# Altium schematic sheet MLSTM32.SchDoc
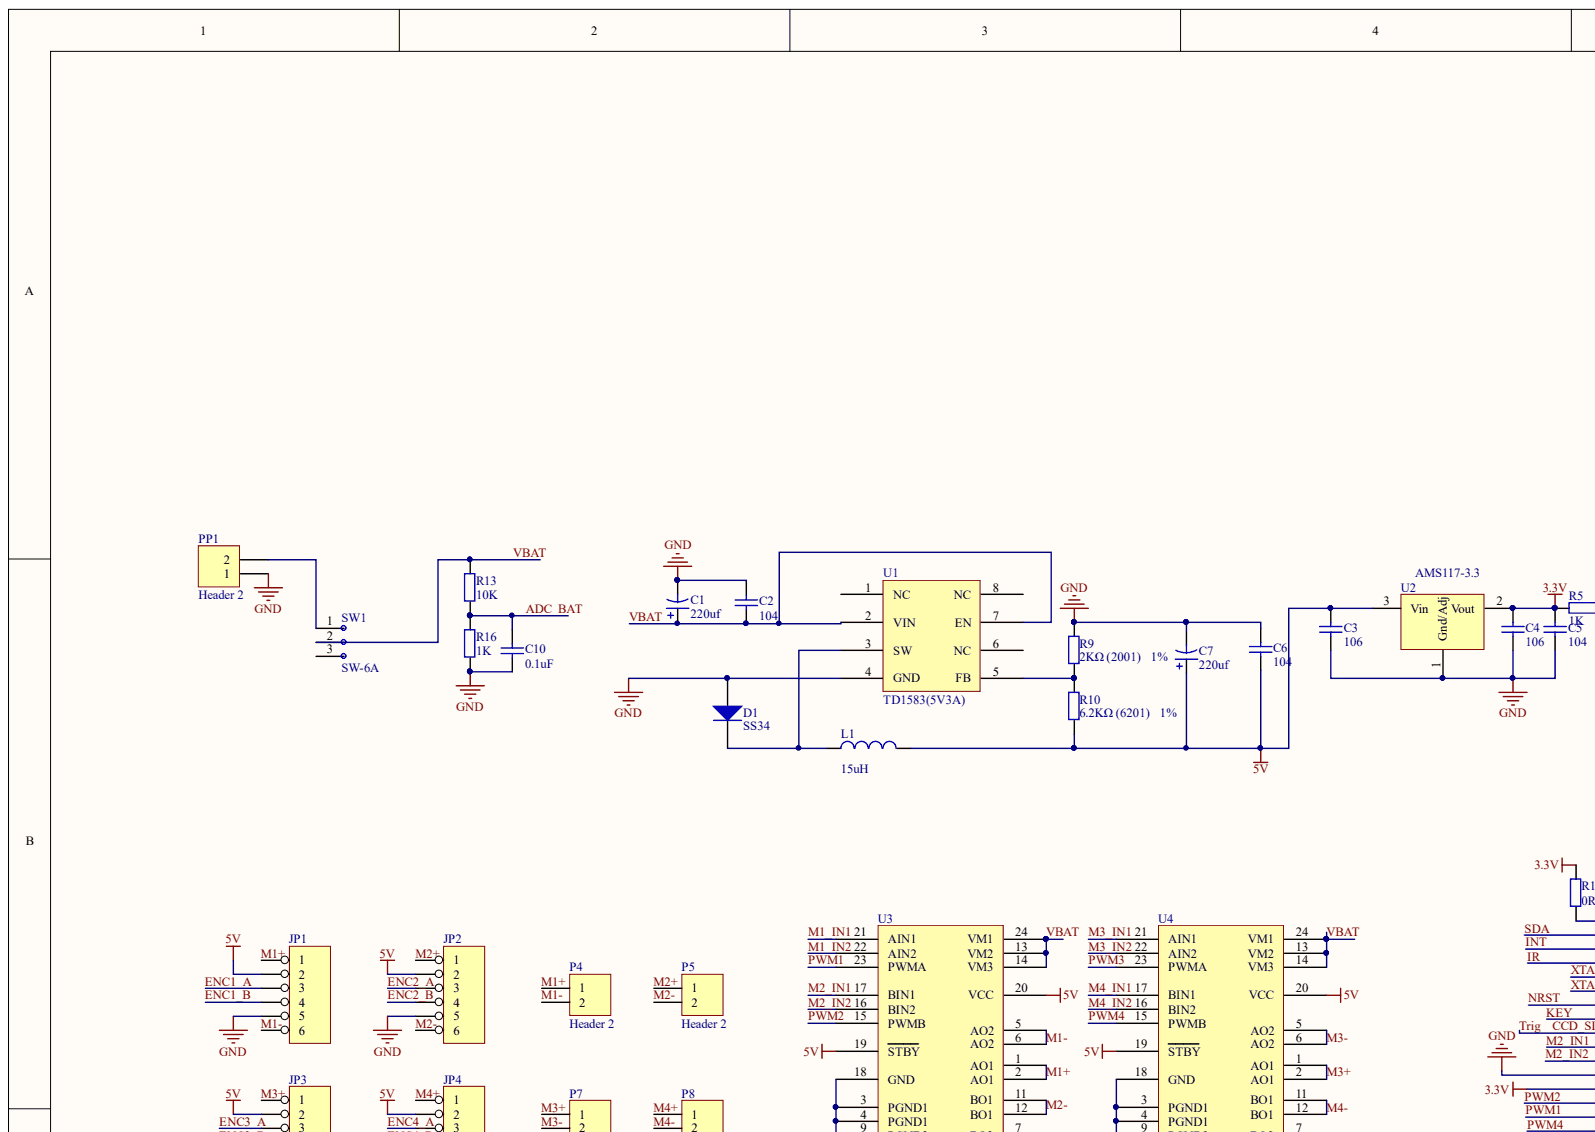
[diagram p0: MLSTM32.SchDoc, top left]
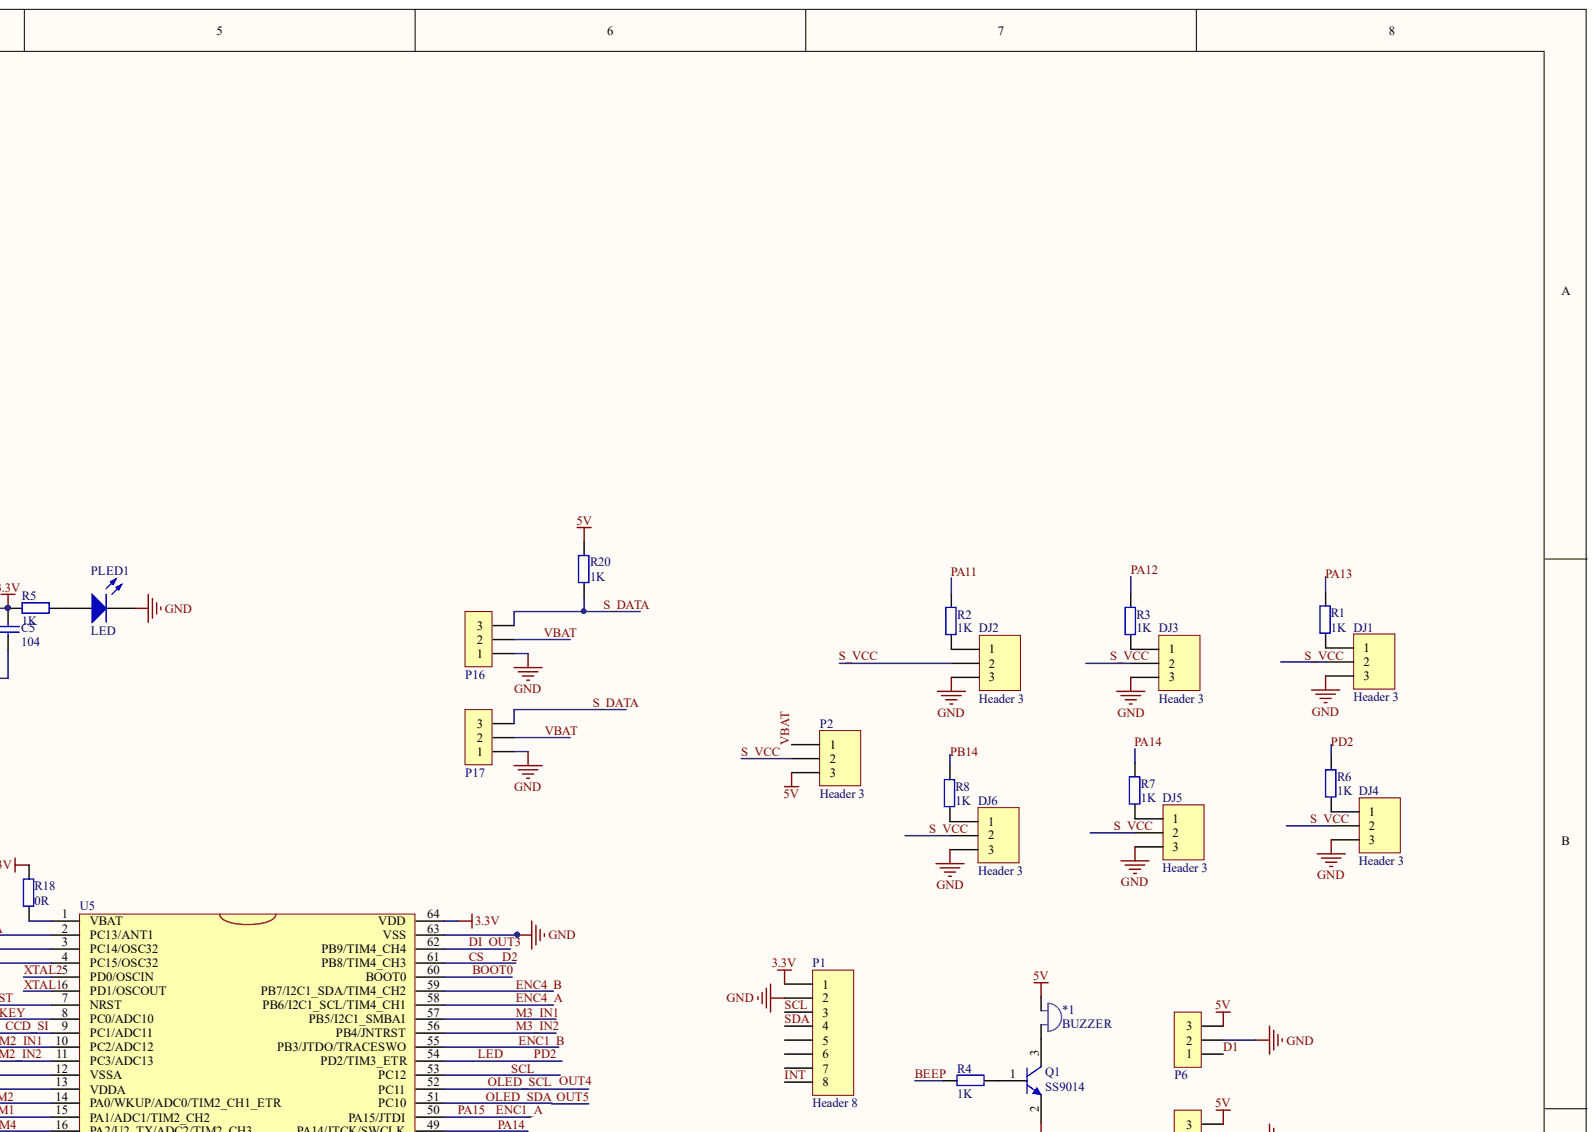
[diagram p1: MLSTM32.SchDoc, top right]
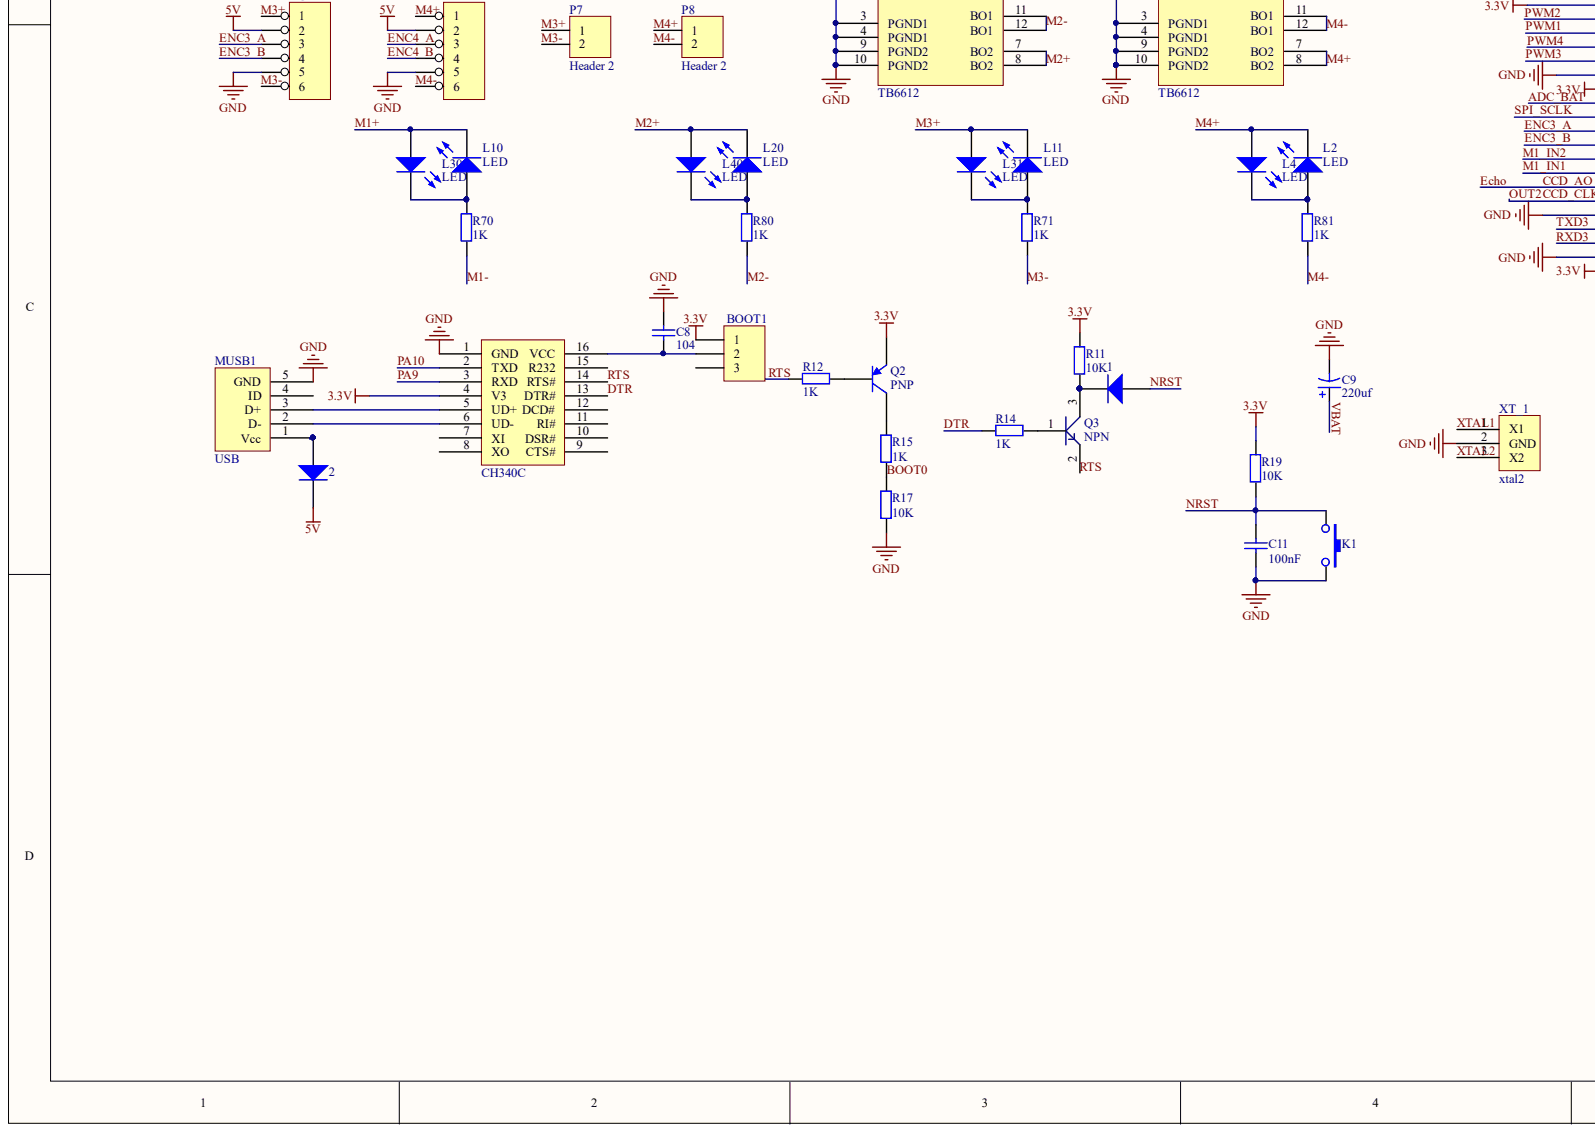
[diagram p2: MLSTM32.SchDoc, bottom left]
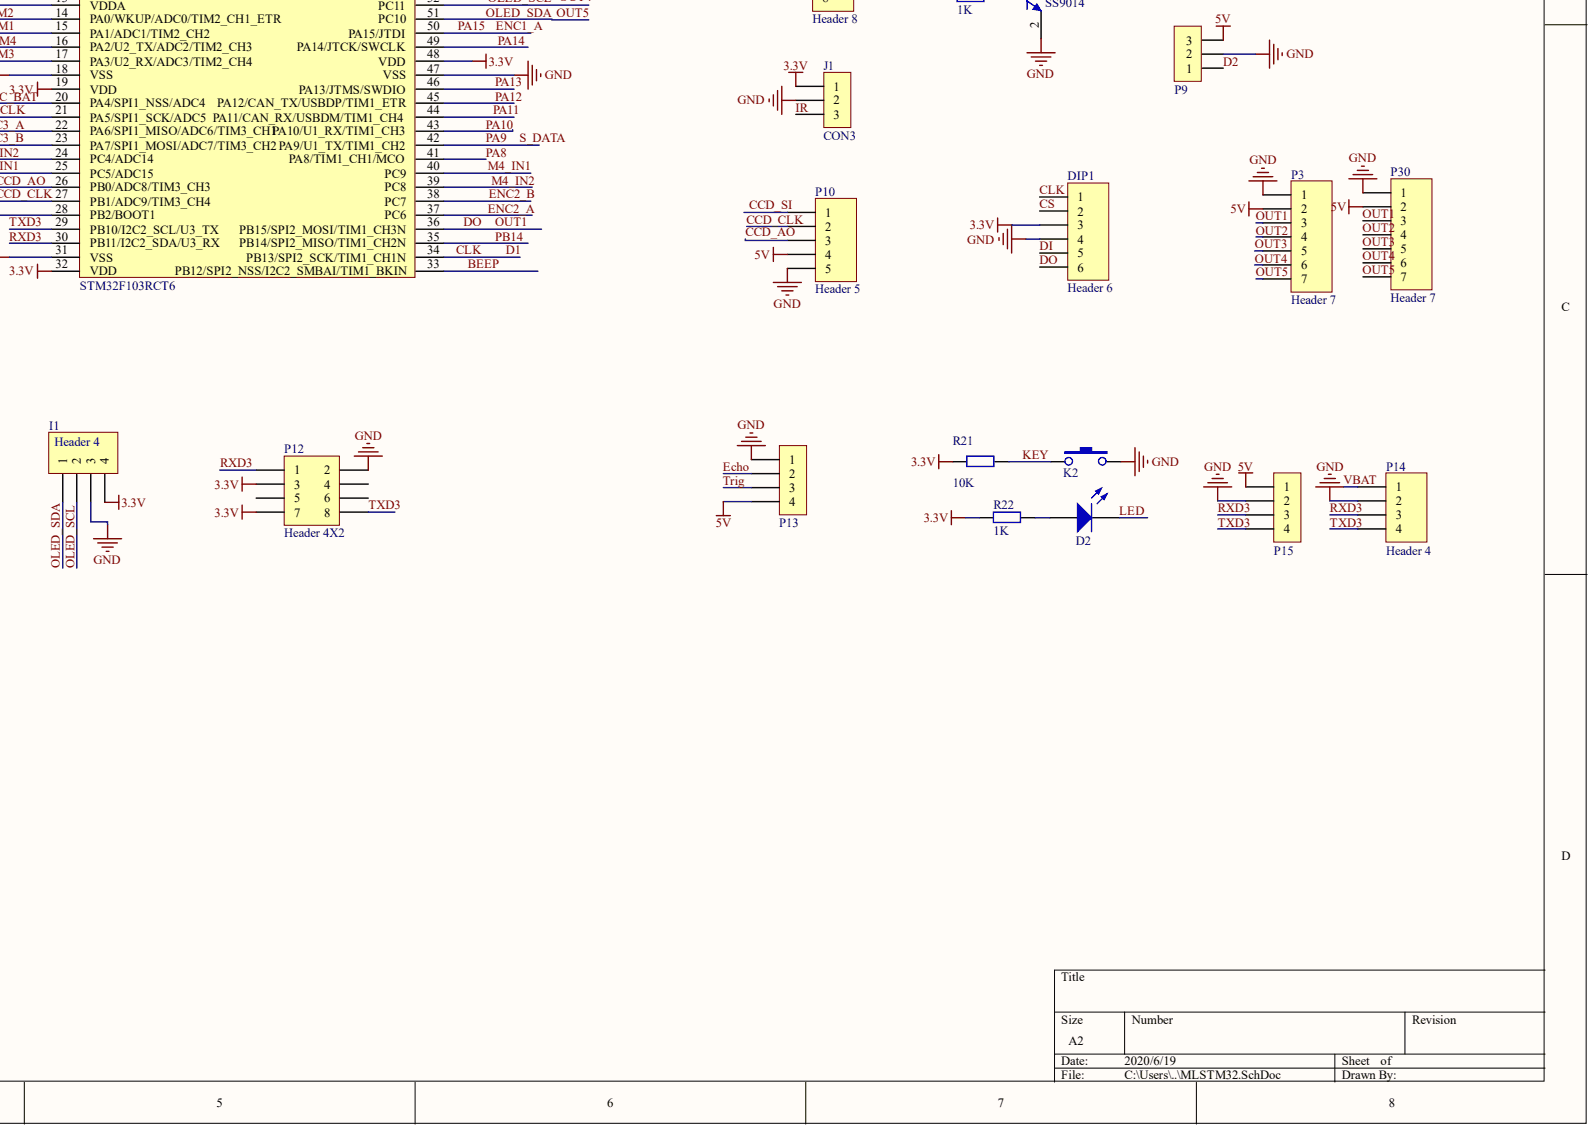
[diagram p3: MLSTM32.SchDoc, bottom right]

## Parts
1  Diode 1N4007  (value not drawn)
2  Diode 1N4007  (value not drawn)
*1  BUZZER
BOOT1  Header 3  (value not drawn)
C1  value 220uf  (hidden comment: Cap Pol1)
C2  value 104  (hidden comment: Cap)
C3  value 106  (hidden comment: Cap)
C4  value 106  (hidden comment: Cap)
C5  value 104  (hidden comment: Cap)
C6  value 104  (hidden comment: Cap)
C7  value 220uf  (hidden comment: Cap Pol1)
C8  value 104  (hidden comment: Cap)
C9  value 220uf  (hidden comment: Cap Pol1)
C10  value 0.1uF  (hidden comment: Cap)
C11  value 100nF  (hidden comment: Cap)
CH1  CH340C
D1  SS34
D2  LED0  (value not drawn)
DIP1  Header 6
DJ1  Header 3
DJ2  Header 3
DJ3  Header 3
DJ4  Header 3
DJ5  Header 3
DJ6  Header 3
I1  Header 4
J1  CON3
JP1  HEADER 6  (value not drawn)
JP2  HEADER 6  (value not drawn)
JP3  HEADER 6  (value not drawn)
JP4  HEADER 6  (value not drawn)
K1  BUTTON  (value not drawn)
K2  BUTTON  (value not drawn)
L1  value 15uH  (hidden comment: 15uH/ CD104R,3A电感)
L2  LED
L4  LED
L10  LED
L11  LED
L20  LED
L30  LED
L31  LED
L40  LED
MUSB1  USB
P1  Header 8
P2  Header 3
P3  Header 7
P4  Header 2
P5  Header 2
P6  CON3  (value not drawn)
P7  Header 2
P8  Header 2
P9  CON3  (value not drawn)
P10  Header 5
P12  Header 4X2
P13  CON4  (value not drawn)
P14  Header 4
P15  CON4  (value not drawn)
P16  CON3  (value not drawn)
P17  CON3  (value not drawn)
P30  Header 7
PLED1  LED
PP1  Header 2
Q1  SS9014
Q2  PNP
Q3  NPN
R1  value 1K  (hidden comment: Res2)
R2  value 1K  (hidden comment: Res2)
R3  value 1K  (hidden comment: Res2)
R4  value 1K  (hidden comment: Res2)
R5  value 1K  (hidden comment: Res2)
R6  value 1K  (hidden comment: Res2)
R7  value 1K  (hidden comment: Res2)
R8  value 1K  (hidden comment: Res2)
R9  2KΩ (2001) ±1%  (value not drawn)
R10  6.2KΩ (6201) ±1%
R11  value 10K  (hidden comment: Res2)
R12  value 1K  (hidden comment: Res2)
R13  value 10K  (hidden comment: Res2)
R14  value 1K  (hidden comment: Res2)
R15  value 1K  (hidden comment: Res2)
R16  value 1K  (hidden comment: Res2)
R17  value 10K  (hidden comment: Res2)
R18  value 0R  (hidden comment: Res1)
R19  value 10K  (hidden comment: Res1)
R20  value 1K  (hidden comment: Res2)
R21  value 10K  (hidden comment: Res1)
R22  value 1K  (hidden comment: Res1)
R70  value 1K  (hidden comment: Res2)
R71  value 1K  (hidden comment: Res2)
R80  value 1K  (hidden comment: Res2)
R81  value 1K  (hidden comment: Res2)
SW1  SW-6A
U1  TD1583(5V3A)
U2  AMS117-3.3
U3  TB6612
U4  TB6612
U5  STM32F103RCT6
XT_1  xtal2

## Nets
3.3V: BOOT1.1 C4.2 C5.2 CH1.4(V3) DIP1.3 I1.4 J1.1 P1.1 P12.3 P12.7 Q2.2 R5.2 R11.1 R18.2 R19.2 R21.1 R22.1 U2.2 U5.13(VDDA) U5.19(VDD) U5.32(VDD) U5.48(VDD) U5.64(VDD)
5V: 2.2 *1.1 C3.2 C6.2 C7.1 JP1.2 JP2.2 JP3.2 JP4.2 L1.2 P2.3 P3.2 P6.3 P9.3 P10.4 P13.4 P15.1 P30.2 R10.1 R20.1 U2.3 U3.19(STBY) U3.20(VCC) U4.19(STBY) U4.20(VCC)
GND: C1.2 C2.2 C3.1 C4.1 C5.1 C6.1 C7.2 C8.2 C9.2 C10.1 C11.1 CH1.1(GND) D1.1 DIP1.4 DJ1.3 DJ2.3 DJ3.3 DJ4.3 DJ5.3 DJ6.3 I1.3 J1.2 JP1.5 JP2.5 JP3.5 JP4.5 K1.2 K2.2 MUSB1.5(GND) P1.2 P3.1 P6.2 P9.2 P10.5 P12.2 P13.1 P14.2 P15.2 P16.1 P17.1 P30.1 PLED1.2 PP1.1 Q1.2 R9.2 R16.2 R17.1 U1.4(GND) U2.1 U3.3(PGND1) U3.4(PGND1) U3.9(PGND2) U3.10(PGND2) U3.18(GND) U4.3(PGND1) U4.4(PGND1) U4.9(PGND2) U4.10(PGND2) U4.18(GND) U5.12(VSSA) U5.18(VSS) U5.28(PB2/BOOT1) U5.31(VSS) U5.47(VSS) U5.63(VSS) XT_1.2
ADC_BAT: C10.2 R13.2 R16.1 U5.20(PA4/SPI1_NSS/ADC4)
BEEP: R4.2 U5.33(PB12/SPI2_NSS/I2C2_SMBAI/TIM1_BKIN)
BOOT0: R15.1 R17.2 U5.60(BOOT0)
CCD_AO: P10.3 P13.2 U5.26(PB0/ADC8/TIM3_CH3)
CCD_CLK: P3.4 P10.2 P30.4 U5.27(PB1/ADC9/TIM3_CH4)
CCD_SI: P10.1 P13.3 U5.9(PC1/ADC11)
CLK: DIP1.1 P6.1 U5.34(PB13/SPI2_SCK/TIM1_CH1N)
CS: DIP1.2 P9.1 U5.61(PB8/TIM4_CH3)
DI: DIP1.5 P3.5 P30.5 U5.62(PB9/TIM4_CH4)
DO: DIP1.6 P3.3 P30.3 U5.36(PB15/SPI2_MOSI/TIM1_CH3N)
DTR: CH1.13(DTR#) R14.2
ENC1_A: JP1.3 U5.50(PA15/JTDI)
ENC1_B: JP1.4 U5.55(PB3/JTDO/TRACESWO)
ENC2_A: JP2.3 U5.37(PC6)
ENC2_B: JP2.4 U5.38(PC7)
ENC3_A: JP3.3 U5.22(PA6/SPI1_MISO/ADC6/TIM3_CH1)
ENC3_B: JP3.4 U5.23(PA7/SPI1_MOSI/ADC7/TIM3_CH2)
ENC4_A: JP4.3 U5.58(PB6/I2C1_SCL/TIM4_CH1)
ENC4_B: JP4.4 U5.59(PB7/I2C1_SDA/TIM4_CH2)
INT: P1.8 U5.3(PC14/OSC32)
IR: J1.3 U5.4(PC15/OSC32)
KEY: K2.1 R21.2 U5.8(PC0/ADC10)
LED: D2.2 R6.1 U5.54(PD2/TIM3_ETR)
M1+: JP1.1 L10.2 L30.1 P4.1 U3.1(AO1) U3.2(AO1)
M1-: JP1.6 P4.2 R70.1 U3.5(AO2) U3.6(AO2)
M1_IN1: U3.21(AIN1) U5.25(PC5/ADC15)
M1_IN2: U3.22(AIN2) U5.24(PC4/ADC14)
M2+: JP2.1 L20.2 L40.1 P5.1 U3.7(BO2) U3.8(BO2)
M2-: JP2.6 P5.2 R80.1 U3.11(BO1) U3.12(BO1)
M2_IN1: U3.17(BIN1) U5.10(PC2/ADC12)
M2_IN2: U3.16(BIN2) U5.11(PC3/ADC13)
M3+: JP3.1 L11.2 L31.1 P7.1 U4.1(AO1) U4.2(AO1)
M3-: JP3.6 P7.2 R71.1 U4.5(AO2) U4.6(AO2)
M3_IN1: U4.21(AIN1) U5.57(PB5/I2C1_SMBAI)
M3_IN2: U4.22(AIN2) U5.56(PB4/JNTRST)
M4+: JP4.1 L2.2 L4.1 P8.1 U4.7(BO2) U4.8(BO2)
M4-: JP4.6 P8.2 R81.1 U4.11(BO1) U4.12(BO1)
M4_IN1: U4.17(BIN1) U5.40(PC9)
M4_IN2: U4.16(BIN2) U5.39(PC8)
NRST: 1.1 C11.2 K1.1 R19.1 U5.7(NRST)
OLED_SCL: I1.2 U5.52(PC11)
OLED_SDA: I1.1 P3.7 P30.7 U5.51(PC10)
OUT4: P3.6 P30.6
PA8: U5.41(PA8/TIM1_CH1/MCO)
PA9: CH1.3(RXD) P16.3 P17.3 R20.2 U5.42(PA9/U1_TX/TIM1_CH2)
PA10: CH1.2(TXD) U5.43(PA10/U1_RX/TIM1_CH3)
PA11: R2.1 U5.44(PA11/CAN_RX/USBDM/TIM1_CH4)
PA12: R3.1 U5.45(PA12/CAN_TX/USBDP/TIM1_ETR)
PA13: R1.1 U5.46(PA13/JTMS/SWDIO)
PA14: R7.1 U5.49(PA14/JTCK/SWCLK)
PB14: R8.1 U5.35(PB14/SPI2_MISO/TIM1_CH2N)
PWM1: U3.23(PWMA) U5.15(PA1/ADC1/TIM2_CH2)
PWM2: U3.15(PWMB) U5.14(PA0/WKUP/ADC0/TIM2_CH1_ETR)
PWM3: U4.23(PWMA) U5.17(PA3/U2_RX/ADC3/TIM2_CH4)
PWM4: U4.15(PWMB) U5.16(PA2/U2_TX/ADC2/TIM2_CH3)
RTS: CH1.14(RTS#) Q3.2 R12.1
RXD3: P12.1 P14.3 P15.3 U5.30(PB11/I2C2_SDA/U3_RX)
S_VCC: DJ1.2 DJ2.2 DJ3.2 DJ4.2 DJ5.2 DJ6.2 P2.2
SCL: P1.3 U5.53(PC12)
SDA: P1.4 U5.2(PC13/ANT1)
SPI_SCLK: U5.21(PA5/SPI1_SCK/ADC5)
TXD3: P12.8 P14.4 P15.4 U5.29(PB10/I2C2_SCL/U3_TX)
VBAT: C1.1 C2.1 C9.1 P2.1 P14.1 P16.2 P17.2 R13.1 SW1.2 U1.2(VIN) U1.7(EN) U3.13(VM2) U3.14(VM3) U3.24(VM1) U4.13(VM2) U4.14(VM3) U4.24(VM1)
XTAL1: U5.6(PD1/OSCOUT) XT_1.1
XTAL2: U5.5(PD0/OSCIN) XT_1.3
Net1_2: 1.2 Q3.3 R11.2
Net2_1: 2.1 MUSB1.1(Vcc)
Net*1_2: *1.2 Q1.3
NetBOOT1_2: BOOT1.2 C8.1 CH1.16(VCC)
NetCH1_5: CH1.5(UD+) MUSB1.3(D+)
NetCH1_6: CH1.6(UD-) MUSB1.2(D-)
NetD1_2: D1.2 L1.1 U1.3(SW)
NetD2_1: D2.1 R22.2
NetDJ1_1: DJ1.1 R1.2
NetDJ2_1: DJ2.1 R2.2
NetDJ3_1: DJ3.1 R3.2
NetDJ4_1: DJ4.1 R6.2
NetDJ5_1: DJ5.1 R7.2
NetDJ6_1: DJ6.1 R8.2
NetL2_1: L2.1 L4.2 R81.2
NetL10_1: L10.1 L30.2 R70.2
NetL11_1: L11.1 L31.2 R71.2
NetL20_1: L20.1 L40.2 R80.2
NetPLED1_1: PLED1.1 R5.1
NetPP1_2: PP1.2 SW1.1
NetQ1_1: Q1.1 R4.1
NetQ2_1: Q2.1 R12.2
NetQ2_3: Q2.3 R15.2
NetQ3_1: Q3.1 R14.1
NetR9_1: R9.1 R10.2 U1.5(FB)
NetR18_1: R18.1 U5.1(VBAT)

Not connected: BOOT1 3; CH1 7(XI) 8(XO) 9(CTS#) 10(DSR#) 11(RI#) 12(DCD#) 15(R232); MUSB1 4(ID); P1 5 6 7; P12 4 5 6; SW1 3; U1 1(NC) 6(NC) 8(NC)

## Library data of the parts
L1 = (no symbol name): Code_IEC: 1005; Published: 8-Jun-2000; LatestRevisionDate: 29-May-2009; LatestRevisionNote: IPC-7351 Footprint Added.; PackageReference: 0402-A; PackageDescription: Chip Inductor; Code_JEITA: 0402; Publisher: Altium Limited
1 2 *1 BOOT1 C1-C11 CH1 D1 D2 DIP1 DJ1-DJ6 I1 J1 JP1-JP4 K1 K2 L2 L4 L10 L11 L20 L30 L31 L40 MUSB1 P1-P10 P12-P17 P30 PLED1 PP1 Q1-Q3 R1-R22 R70 R71 R80 R81 SW1 U1-U5 XT_1 = (no symbol name)
  1 2: Published: 8-Jun-2000; LatestRevisionDate: 17-Jul-2002; LatestRevisionNote: Re-released for DXP Platform.; DatasheetDocument: 1998; PackageDocument: Aug-1999; PackageReference: DO-41; Code_JEDEC: DO-204-AL; ComponentLink1Description: Manufacturer Link; ComponentLink1URL: http://www.fairchildsemi.com/; ComponentLink2Description: Datasheet; ComponentLink2URL: http://www.fairchildsemi.com/ds/1N%2F1N4001.pdf
  BOOT1 DJ1-DJ6 I1 P1-P5 P7 P8 P10 P12 P14 P30 PP1: LatestRevisionDate: 17-Jul-2002; LatestRevisionNote: Re-released for DXP Platform.
  C1 C7 C9: Published: 8-Jun-2000; LatestRevisionDate: 17-Jul-2002; LatestRevisionNote: Re-released for DXP Platform.; PackageReference: RB7.6-15
  C2-C6 C8 C10 C11: Published: 8-Jun-2000; LatestRevisionDate: 17-Jul-2002; LatestRevisionNote: Re-released for DXP Platform.; PackageReference: RAD-0.3
  D1: Published: 8-Jun-2000; LatestRevisionDate: 17-Jul-2002; LatestRevisionNote: Re-released for DXP Platform.; PackageVersion: Sep-1996; PackageReference: SMC; PackageDescription: DO-214-AB/SMC; 2 C-Bend Leads; Body 7.9 x 5.9 mm, inc. leads (LxW); Code_JEDEC: DO-214-AB; Value: SS34
  D2: Published: 8-Jun-2000; LatestRevisionDate: 08-Jul-2005; LatestRevisionNote: Mech Layer 1 removed.; PackageReference: LED-0
  Q1: Published: 8-Jun-2000; LatestRevisionDate: 15-Jan-2003; LatestRevisionNote: PCB Footprint 'BCY-W3/D4.7' replaced; DatasheetDocument: 1997; PackageDocument: Sep-1998; PackageReference: TO-92A; Code_JEDEC: TO-92A; ComponentLink1Description: Manufacturer Link; ComponentLink1URL: http://www.fairchildsemi.com/; ComponentLink2Description: Datasheet; ComponentLink2URL: http://www.fairchildsemi.com/ds/2N%2F2N3904.pdf
  R1-R8 R11-R19 R21 R22 R70 R71 R80 R81: Published: 8-Jun-2000; LatestRevisionDate: 17-Jul-2002; LatestRevisionNote: Re-released for DXP Platform.; PackageReference: AXIAL-0.4
  R9: ComponentLink1Description: 供应商链接; ComponentLink1URL: http://www.szlcsc.com/product/details_23702.html; Package: 0603; Supplier: LC; SuppliersPartNumber: C22975
  R10: ComponentLink1Description: 供应商链接; ComponentLink1URL: http://www.szlcsc.com/product/details_4667.html; Package: 0603; Supplier: LC; SuppliersPartNumber: C4260
  R20: Published: 8-Jun-2000; LatestRevisionDate: 17-Jul-2002; LatestRevisionNote: Re-released for DXP Platform.; PackageReference: AXIAL-0.4; PackageDescription: Axial Device, Thru-Hole; 2 Leads; 0.4 in Pin Spacing
  1 2 BOOT1 C1-C11 D1 D2 DJ1-DJ6 I1 P1-P5 P7 P8 P10 P12 P14 P30 PP1 Q1 R1-R8 R11-R22 R70 R71 R80 R81: Publisher: Altium Limited
